# Altium schematic sheet [3]comm.SchDoc([3]comm)
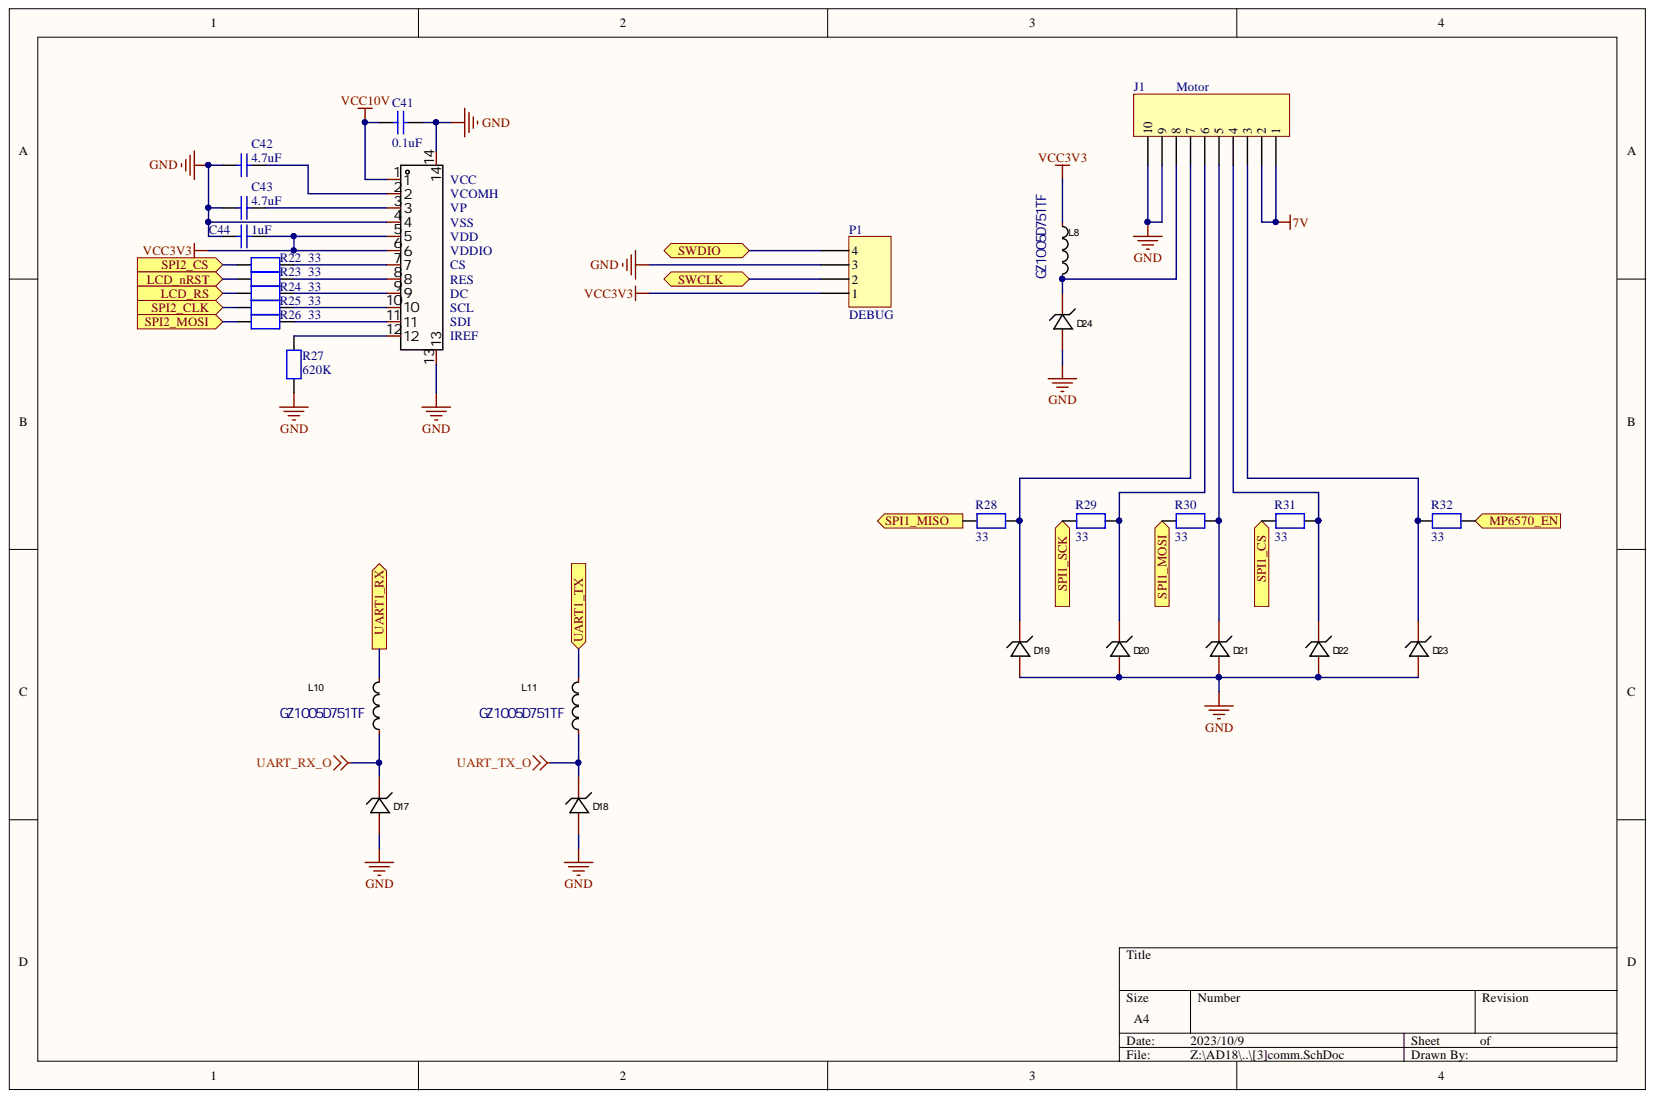
[diagram p0: [3]comm.SchDoc]

## Parts
C41  0.1uF  [C0402]
C42  4.7uF  [C0603]
C43  4.7uF  [C0603]
C44  1uF  [C0402]
D17  TPD1E05U06DPYR  [X2SON-2_L1.0-W0.6-P0.70-RD]  (value not drawn)
D18  TPD1E05U06DPYR  [X2SON-2_L1.0-W0.6-P0.70-RD]  (value not drawn)
D19  TPD1E05U06DPYR  [X2SON-2_L1.0-W0.6-P0.70-RD]  (value not drawn)
D20  TPD1E05U06DPYR  [X2SON-2_L1.0-W0.6-P0.70-RD]  (value not drawn)
D21  TPD1E05U06DPYR  [X2SON-2_L1.0-W0.6-P0.70-RD]  (value not drawn)
D22  TPD1E05U06DPYR  [X2SON-2_L1.0-W0.6-P0.70-RD]  (value not drawn)
D23  TPD1E05U06DPYR  [X2SON-2_L1.0-W0.6-P0.70-RD]  (value not drawn)
D24  TPD1E05U06DPYR  [X2SON-2_L1.0-W0.6-P0.70-RD]  (value not drawn)
J1  Motor  [MOT_SIP10]
L8  GZ1005D751TF  [L0402]
L10  GZ1005D751TF  [L0402]
L11  GZ1005D751TF  [L0402]
P1  DEBUG  [HDR1X4]
R22  33  [R0402]
R23  33  [R0402]
R24  33  [R0402]
R25  33  [R0402]
R26  33  [R0402]
R27  620K  [R0402]
R28  33  [R0402]
R29  33  [R0402]
R30  33  [R0402]
R31  33  [R0402]
R32  33  [R0402]
U7  FH34SRJ-12S-0.5SH(50)  [FFC-SMD_12P-P0.50_FH34SRJ-12S-0.5SH]  (value not drawn)

## Ports
LCD_nRST, LCD_RS, MP6570_EN, SPI1_CS, SPI1_MISO, SPI1_MOSI, SPI1_SCK, SPI2_CLK, SPI2_CS, SPI2_MOSI, SWCLK, SWDIO, UART1_RX, UART1_TX

## Nets
7V: J1.1 J1.2
GND: C41.1 C42.1 C43.1 C44.2 D17.2 D18.2 D19.2 D20.2 D21.2 D22.2 D23.2 D24.2 J1.9 J1.10 P1.3 R27.2 U7.4 U7.13 U7.14
UART_RX_O: D17.1 L10.1
UART_TX_O: D18.1 L11.1
VCC3V3: C44.1 L8.1 P1.1 U7.5 U7.6
VCC10V: C41.2 U7.1
LCD_nRST: R23.1 ; port LCD_nRST
LCD_RS: R24.1 ; port LCD_RS
MP6570_EN: R32.2 ; port MP6570_EN
SPI1_CS: R31.1 ; port SPI1_CS
SPI1_MISO: R28.1 ; port SPI1_MISO
SPI1_MOSI: R30.1 ; port SPI1_MOSI
SPI1_SCK: R29.1 ; port SPI1_SCK
SPI2_CLK: R25.1 ; port SPI2_CLK
SPI2_CS: R22.1 ; port SPI2_CS
SPI2_MOSI: R26.1 ; port SPI2_MOSI
SWCLK: P1.2 ; port SWCLK
SWDIO: P1.4 ; port SWDIO
UART1_RX: L10.2 ; port UART1_RX
UART1_TX: L11.2 ; port UART1_TX
NetC42_2: C42.2 U7.2
NetC43_2: C43.2 U7.3
NetD19_1: D19.1 J1.7 R28.2
NetD20_1: D20.1 J1.6 R29.2
NetD21_1: D21.1 J1.5 R30.2
NetD22_1: D22.1 J1.4 R31.2
NetD23_1: D23.1 J1.3 R32.1
NetD24_1: D24.1 J1.8 L8.2
NetR22_2: R22.2 U7.7
NetR23_2: R23.2 U7.8
NetR24_2: R24.2 U7.9
NetR25_2: R25.2 U7.10
NetR26_2: R26.2 U7.11
NetR27_1: R27.1 U7.12

## Library data of the parts
C41 = (no symbol name): Capacitor, MLCC, 0.1uF, 25V, NPO, +-0.25pF, 0402, T=0.5mm; Manufacturer_Part_Number: CC0402CRNPO9BN5R1; Manufacturer: YAGEO; Internal_Part_Number: MCD-00003; Provided_By: SUBCO; ECCN_US: EAR99; ECCN_EU: NEC; Value: 0.1uF; Status: Released; Part_Type: Capacitor; Package: C0402; Library Name: mb1367.IntLib; ComponentLink1URL: http://tunmcdsvn.tun.st.com/Component_Datasheet_Library/Trunk/Capacitors/Yageo_Capacitor_NP0_16V-to-50V; ComponentLink1Description: Datasheet; Component Kind: Standard
C42 C43 = (no symbol name): Capacitor, MLCC, 4.7uF, 25V, X5R, 10%, 0603, T=0.8mm; Manufacturer_Part_Number: CC0603KRX5R7BB475; Manufacturer: YAGEO; Internal_Part_Number: MCD-00009; Provided_By: subcon; Initial_Board_Reference: MB1274; Value: 4.7uF; Status: Released; Part_Type: Capacitor; Package: C0603; Library Name: mb1367.IntLib; ECCN_US: n/a; ECCN_EU: n/a; ComponentLink1URL: http://tunmcdsvn.tun.st.com/Component_Datasheet_Library/Trunk/Capacitors/Yageo_Multilayer_capacitor_X5R_series_100pF_to_100uF; ComponentLink1Description: Yageo_Capacitor_X5R-series.pdf; Component Kind: Standard
C44 = (no symbol name): Capacitor, MLCC, 1uF, 16V, NPO, +-0.25pF, 0402, T=0.5mm; Manufacturer_Part_Number: CC0402CRNPO9BN5R1; Manufacturer: YAGEO; Internal_Part_Number: MCD-00003; Provided_By: SUBCO; ECCN_US: EAR99; ECCN_EU: NEC; Value: 1uF; Status: Released; Part_Type: Capacitor; Package: C0402; Library Name: mb1367.IntLib; ComponentLink1URL: http://tunmcdsvn.tun.st.com/Component_Datasheet_Library/Trunk/Capacitors/Yageo_Capacitor_NP0_16V-to-50V; ComponentLink1Description: Datasheet; Component Kind: Standard
D17-D24 = TPD1E05U06DPYR: TVS 单向   反向截止电压(Vrwm)5.5V@Max  击穿电压6V  峰值脉冲电流(Ipp)@10/1000μs  2.5A@8/20us   最大钳位电压14V; Manufacturer: TI(德州仪器); Maximum Clamping Voltage: 14V; Convert to PCB: yes; Breakdown Voltage: 6V; Symbol: TPD1E05U06DPYR; Supplier Part: C436349; Supplier Footprint: X2-SON-2(0.6x1); Supplier: LCSC; Reverse Stand-Off Voltage (Vrwm): 5.5V@Max; Polarity: 单向; Peak Pulse Current (Ipp)@10/1000μs: 2.5A@8/20us; PCB3D: X2SON-2_L1.0-W0.6-H0.4-P0.70-RD; Name: TPD1E05U06DPYR; Manufacturer Part: TPD1E05U06DPYR; Library Name: HiPin_Library_AD.IntLib; LCSC Part Name: 单向 Vrwm:5.5V@Max; JLCPCB Part Class: Extended Part; Device: TPD1E05U06DPYR; Datasheet: https://atta.szlcsc.com/upload/public/pdf/source/20191016/C436349_486749D4EDA8974C8ED360A45B982565.pdf; Component Kind: Standard; Add into BOM: yes
J1 = CON10: 马达连接排线; ManufacturerNumber: FH34SRJ-10S-0.5SH; Manufacturer: HRS(广濑）; SuppliersPartNumber: C324723; Component Kind: Standard
L8 L10 L11 = GZ1005D751TF: 磁珠 750Ω@100MHz  ±25%   直流电阻(RDC) 1ohm  额定电流 100mA; Manufacturer: Sunlord(顺络); DC Resistance: 1Ω; Convert to PCB: yes; Circuits: 1; Tolerance: ±25%; Symbol: GZ1005D751TF; Supplier Part: C96684; Supplier Footprint: 0402; Supplier: LCSC; PCB3D: L0402_L1.0-W0.5-H0.5_BEAD; Name: GZ1005D751TF; Manufacturer Part: GZ1005D751TF; Library Name: HiPin_Library_AD.IntLib; LCSC Part Name: 750Ω@100MHz ±25%; JLCPCB Part Class: Extended Part; Impedance @ Frequency: 750Ω@100MHz; Device: GZ1005D751TF; Datasheet: https://atta.szlcsc.com/upload/public/pdf/source/20210830/355EA7C0FAC5394FC0FE3F17C770C20A.pdf; Current Rating: 100mA; Component Kind: Standard; Add into BOM: yes
P1 = Header 4: Header, 4-Pin; Library Name: Miscellaneous Connectors.IntLib; LatestRevisionNote: Re-released for DXP Platform.; LatestRevisionDate: 17-Jul-2002; Component Kind: Standard; Publisher: Altium Limited
R22-R32 = RES: Resistor; Library Name: MB1297 revC.IntLib; Component Kind: Standard
U7 = FH34SRJ-12S-0.5SH(50): Manufacturer: HRS(广濑); Pitch: 0.5mm; Number of Contacts: 12; Locking Feature: 翻盖式; FFC, FCB Thickness: 0.3mm; Convert to PCB: yes; Symbol: FH34SRJ-12S-0.5SH(50); Supplier Part: C424659; Supplier Footprint: SMD,P=0.5mm; Supplier: LCSC; Name: FH34SRJ-12S-0.5SH(50); Mounting Type: 卧贴; Manufacturer Part: FH34SRJ-12S-0.5SH(50); LCSC Part Name: 0.5mm P数:12 翻盖式 双侧触点/上下接 FH34系列后锁式; JLCPCB Part Class: Extended Part; Height Above Board: 1mm; Device: FH34SRJ-12S-0.5SH(50); Datasheet: https://atta.szlcsc.com/upload/public/pdf/source/20190903/C424659_DFD3BE3BB7EA5B6250138E199E6FD749.pdf; Contact Type: 双侧触点/上下接; Component Kind: Standard; Add into BOM: yes
